# Altium schematic sheet Schematic_Orbita_Motor_Control.SchDoc
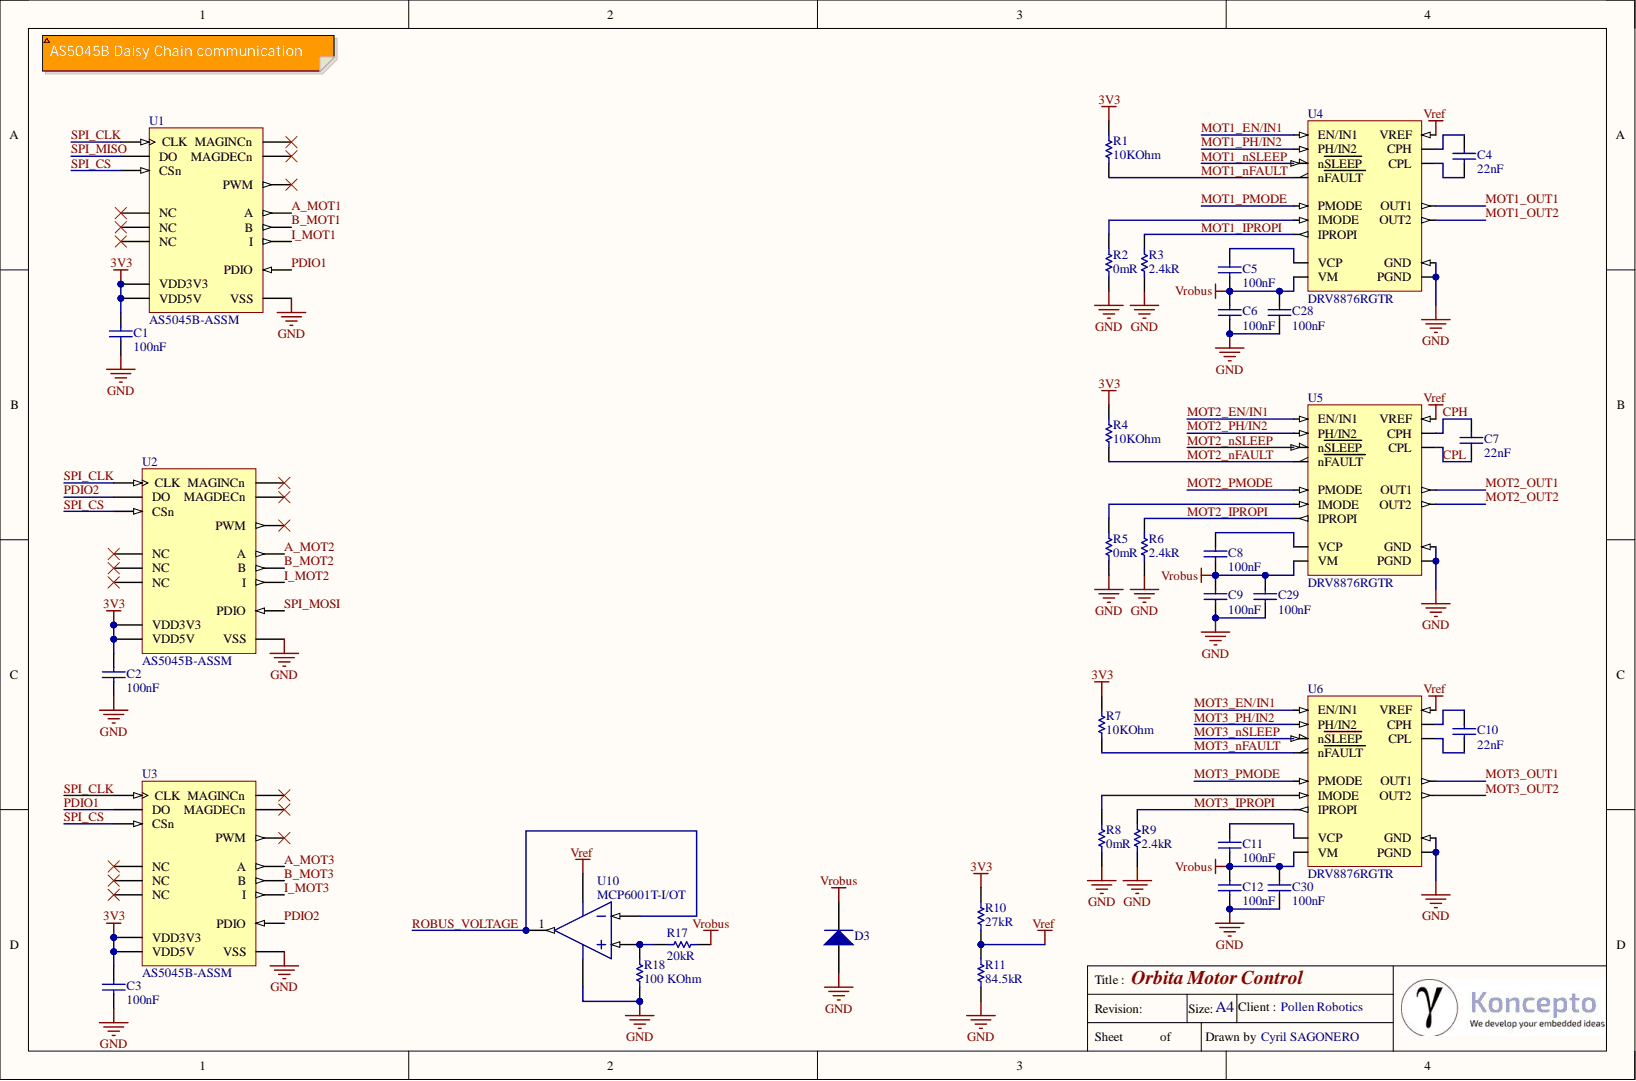
[diagram p0: Schematic_Orbita_Motor_Control.SchDoc]

## Parts
C1  Capacitance: 100nF  [CAPC1005X60X30ML05T10]  (hidden comment: C0402C104K8PACTU)
C2  Capacitance: 100nF  [CAPC1005X60X30ML05T10]  (hidden comment: C0402C104K8PACTU)
C3  Capacitance: 100nF  [CAPC1005X60X30ML05T10]  (hidden comment: C0402C104K8PACTU)
C4  Capacitance: 22nF  [CAPC1005X55X30ML05T10]  (hidden comment: C0402C223K5RACTU)
C5  Capacitance: 100nF  [CAPC1005X55X25ML05T10]  (hidden comment: GRM155R71C104KA88D)
C6  Capacitance: 100nF  [CAPC1005X55X25ML05T10]  (hidden comment: GRM155R71C104KA88D)
C7  Capacitance: 22nF  [CAPC1005X55X30ML05T10]  (hidden comment: C0402C223K5RACTU)
C8  Capacitance: 100nF  [CAPC1005X55X25ML05T10]  (hidden comment: GRM155R71C104KA88D)
C9  Capacitance: 100nF  [CAPC1005X55X25ML05T10]  (hidden comment: GRM155R71C104KA88D)
C10  Capacitance: 22nF  [CAPC1005X55X30ML05T10]  (hidden comment: C0402C223K5RACTU)
C11  Capacitance: 100nF  [CAPC1005X55X25ML05T10]  (hidden comment: GRM155R71C104KA88D)
C12  Capacitance: 100nF  [CAPC1005X55X25ML05T10]  (hidden comment: GRM155R71C104KA88D)
C28  Capacitance: 100nF  [CAPC1005X55X25ML05T10]  (hidden comment: GRM155R71C104KA88D)
C29  Capacitance: 100nF  [CAPC1005X55X25ML05T10]  (hidden comment: GRM155R71C104KA88D)
C30  Capacitance: 100nF  [CAPC1005X55X25ML05T10]  (hidden comment: GRM155R71C104KA88D)
D3  SMAJ13A-E3/61  [VISH-DO-214AC-2_L]  (value not drawn)
R1  Resistance: 10KOhm  [RESC1005X40X25LL05T05]  (hidden comment: ERJ2RKF1002X)
R2  Resistance: 0mR  [RESC1005X40X25NL05T10]  (hidden comment: RC0402JR-070RL)
R3  Resistance: 2.4kR  [RESC2013X60X40LL20T25]  (hidden comment: ERA-6AEB242V)
R4  Resistance: 10KOhm  [RESC1005X40X25LL05T05]  (hidden comment: ERJ2RKF1002X)
R5  Resistance: 0mR  [RESC1005X40X25NL05T10]  (hidden comment: RC0402JR-070RL)
R6  Resistance: 2.4kR  [RESC2013X60X40LL20T25]  (hidden comment: ERA-6AEB242V)
R7  Resistance: 10KOhm  [RESC1005X40X25LL05T05]  (hidden comment: ERJ2RKF1002X)
R8  Resistance: 0mR  [RESC1005X40X25NL05T10]  (hidden comment: RC0402JR-070RL)
R9  Resistance: 2.4kR  [RESC2013X60X40LL20T25]  (hidden comment: ERA-6AEB242V)
R10  Resistance: 27kR  [RESC1005X40X25ML05T05]  (hidden comment: CRCW040227K0FKED)
R11  Resistance: 84.5kR  [RESC1005X40X25ML05T05]  (hidden comment: CRCW040284K5FKED)
R17  Resistance: 20kR  [RESC1005X40X25NL05T05]  (hidden comment: CRCW040220K0FKED)
R18  Resistance: 100 KOhm  [RESC1005X40X25LL05T05]  (hidden comment: ERJ-2RKF1003X)
U1  AS5045B-ASSM  Output Type: PWM  [AMS-SSOP-16-6200X5300DE_L]
U2  AS5045B-ASSM  Output Type: PWM  [AMS-SSOP-16-6200X5300DE_L]
U3  AS5045B-ASSM  Output Type: PWM  [AMS-SSOP-16-6200X5300DE_L]
U4  DRV8876RGTR  [VQFN-16]
U5  DRV8876RGTR  [VQFN-16]
U6  DRV8876RGTR  [VQFN-16]
U10  MCP6001T-I/OT  [SOT-23-OT5_L]

## Nets
3V3: C1.2 C2.2 C3.2 R1.2 R4.1 R7.1 R10.1 U1.15(VDD3V3) U1.16(VDD5V) U2.15(VDD3V3) U2.16(VDD5V) U3.15(VDD3V3) U3.16(VDD5V)
GND: C1.1 C2.1 C3.1 C6.2 C9.2 C12.2 C28.2 C29.2 C30.2 D3.2 R2.2 R3.2 R5.2 R6.2 R8.2 R9.2 R11.2 R18.1 U1.7(VSS) U2.7(VSS) U3.7(VSS) U4.7(PGND) U4.13(GND) U5.7(PGND) U5.13(GND) U6.7(PGND) U6.13(GND) U10.2
Vref: R10.2 R11.1 U4.3(VREF) U5.3(VREF) U6.3(VREF) U10.5
Vrobus: C5.2 C6.1 C8.2 C9.1 C11.2 C12.1 C28.1 C29.1 C30.1 D3.1 R17.1 U4.9(VM) U5.9(VM) U6.9(VM)
A_MOT1: U1.3(A)
A_MOT2: U2.3(A)
A_MOT3: U3.3(A)
B_MOT1: U1.4(B)
B_MOT2: U2.4(B)
B_MOT3: U3.4(B)
CPH: C7.1 U5.11(CPH)
CPL: C7.2 U5.12(CPL)
I_MOT1: U1.6(I)
I_MOT2: U2.6(I)
I_MOT3: U3.6(I)
MOT1_EN/IN1: U4.15(EN/IN1)
MOT1_IPROPI: R3.1 U4.4(IPROPI)
MOT1_nFAULT: R1.1 U4.2(nFAULT)
MOT1_nSLEEP: U4.1(nSLEEP)
MOT1_OUT1: U4.6(OUT1)
MOT1_OUT2: U4.8(OUT2)
MOT1_PH/IN2: U4.16(PH/IN2)
MOT1_PMODE: U4.14(PMODE)
MOT2_EN/IN1: U5.15(EN/IN1)
MOT2_IPROPI: R6.1 U5.4(IPROPI)
MOT2_nFAULT: R4.2 U5.2(nFAULT)
MOT2_nSLEEP: U5.1(nSLEEP)
MOT2_OUT1: U5.6(OUT1)
MOT2_OUT2: U5.8(OUT2)
MOT2_PH/IN2: U5.16(PH/IN2)
MOT2_PMODE: U5.14(PMODE)
MOT3_EN/IN1: U6.15(EN/IN1)
MOT3_IPROPI: R9.1 U6.4(IPROPI)
MOT3_nFAULT: R7.2 U6.2(nFAULT)
MOT3_nSLEEP: U6.1(nSLEEP)
MOT3_OUT1: U6.6(OUT1)
MOT3_OUT2: U6.8(OUT2)
MOT3_PH/IN2: U6.16(PH/IN2)
MOT3_PMODE: U6.14(PMODE)
PDIO1: U1.8(PDIO) U3.9(DO)
PDIO2: U2.9(DO) U3.8(PDIO)
ROBUS_VOLTAGE: U10.1 U10.4
SPI_CLK: U1.10(CLK) U2.10(CLK) U3.10(CLK)
SPI_CS: U1.11(CSn) U2.11(CSn) U3.11(CSn)
SPI_MISO: U1.9(DO)
SPI_MOSI: U2.8(PDIO)
NetC4_1: C4.1 U4.11(CPH)
NetC4_2: C4.2 U4.12(CPL)
NetC5_1: C5.1 U4.10(VCP)
NetC8_1: C8.1 U5.10(VCP)
NetC10_1: C10.1 U6.11(CPH)
NetC10_2: C10.2 U6.12(CPL)
NetC11_1: C11.1 U6.10(VCP)
NetR2_1: R2.1 U4.5(IMODE)
NetR5_1: R5.1 U5.5(IMODE)
NetR8_1: R8.1 U6.5(IMODE)
NetR17_2: R17.2 R18.2 U10.3

Not connected: U1 1(MAGINCn) 2(MAGDECn) 5(NC) 12(PWM) 13(NC) 14(NC); U2 1(MAGINCn) 2(MAGDECn) 5(NC) 12(PWM) 13(NC) 14(NC); U3 1(MAGINCn) 2(MAGDECn) 5(NC) 12(PWM) 13(NC) 14(NC)

## Library data of the parts
C1-C3 = CMP-2008-02046-1: Manufacturer: Kemet; Dielectric: X5R; Dielectric Material: Ceramic; Dissipation Factor: 5%; Tolerance: 10%; Voltage: 10V; Case Code (Imperial): 0402; Case Code (Metric): 1005; Case/Package: 0402; Category: Ceramic Capacitors; Component Kind: Standard; ComponentLink1Description: https://datasheet.ciiva.com/7747/1776086-7747440.pdf?src-supplier=Element14; ComponentLink1URL: https://datasheet.ciiva.com/7747/1776086-7747440.pdf?src-supplier=Element14; ComponentLink2Description: https://datasheet.ciiva.com/144/docfinderpartnumber-c0402c104k8pactu-144097.pdf?src-supplier=Digi-Key; ComponentLink2URL: https://datasheet.ciiva.com/144/docfinderpartnumber-c0402c104k8pactu-144097.pdf?src-supplier=Digi-Key; ComponentLink3Description: https://datasheet.ciiva.com/14595/70095383-14595064.pdf?src-supplier=Allied+Electronics; ComponentLink3URL: https://datasheet.ciiva.com/14595/70095383-14595064.pdf?src-supplier=Allied+Electronics; ComponentLink4Description: https://datasheet.ciiva.com/14588/70095383-14588187.pdf?src-supplier=Allied+Electronics; ComponentLink4URL: https://datasheet.ciiva.com/14588/70095383-14588187.pdf?src-supplier=Allied+Electronics; ComponentLink5Description: https://datasheet.ciiva.com/28645/1789732-28645387.pdf?src-supplier=Element14; ComponentLink5URL: https://datasheet.ciiva.com/28645/1789732-28645387.pdf?src-supplier=Element14; Contact Plating: Tin,Matte; Depth: 0.5mm; Height: 0.5mm; Lead Free: Lead Free; Lead Pitch: 0.3mm; Length: 1mm; Library Name: Altium Content Vault; Manufacturer Part Number: C0402C104K8PACTU; Max Operating Temperature: 85°C; Min Operating Temperature: -55°C; Mount: Surface Mount,PCB; Number of Pins: 2; Package Quantity: 1; Packaging: Tape and Reel; Part Number: C0402C104K8PACTU; Pricing 1: 1=0,25000, 10=0,05500, 100=0,03300, 500=0,02800, 1000=0,02200, 2000=0,01800 (USD); Radiation Hardening: No; REACH SVHC: No SVHC; RoHS: Yes; Stock 1: 986290; Supplier 1: Mouser; Supplier Part Number 1: 80-C0402C104K8P; Termination: SMD/SMT; Thickness: 0.022inch; Voltage Rating: 10V; Voltage Rating (DC): 10V; Width: 0.02inch
C4 C7 C10 = CMP-2008-03151-1: Manufacturer: Kemet; Dielectric: X7R; Dielectric Material: Ceramic; Dissipation Factor: 2.5%; Tolerance: 10%; Voltage: 50V; Case Code (Imperial): 0402; Case Code (Metric): 1005; Case/Package: 0402; Category: Ceramic Capacitors; Component Kind: Standard; ComponentLink1Description: https://datasheet.ciiva.com/14598/70095383-14598149.pdf?src-supplier=Allied+Electronics; ComponentLink1URL: https://datasheet.ciiva.com/14598/70095383-14598149.pdf?src-supplier=Allied+Electronics; ComponentLink2Description: https://datasheet.ciiva.com/7747/40889-7747499.pdf?src-supplier=Element14; ComponentLink2URL: https://datasheet.ciiva.com/7747/40889-7747499.pdf?src-supplier=Element14; ComponentLink3Description: https://datasheet.ciiva.com/7747/40889-7747500.pdf?src-supplier=Element14; ComponentLink3URL: https://datasheet.ciiva.com/7747/40889-7747500.pdf?src-supplier=Element14; ComponentLink4Description: https://datasheet.ciiva.com/14609/70095383-14609037.pdf?src-supplier=Allied+Electronics; ComponentLink4URL: https://datasheet.ciiva.com/14609/70095383-14609037.pdf?src-supplier=Allied+Electronics; Contact Plating: Tin,Matte; Depth: 0.5mm; Height: 0.5mm; Length: 1mm; Library Name: Altium Content Vault; Manufacturer Part Number: C0402C223K5RACTU; Max Operating Temperature: 125°C; Min Operating Temperature: -55°C; Mount: Surface Mount,PCB; Number of Pins: 2; Package Quantity: 1; Packaging: Tape and Reel; Part Number: C0402C223K5RACTU; Pricing 1: 1=0,11000, 10=0,08000, 100=0,03500, 500=0,02500, 1000=0,01900, 2000=0,01600 (USD); Radiation Hardening: No; REACH SVHC: No SVHC; RoHS: Yes; Stock 1: 119647; Supplier 1: Mouser; Supplier Part Number 1: 80-C0402C223K5R; Termination: SMD/SMT; Thickness: 0.022inch; Voltage Rating: 50V; Voltage Rating (DC): 50V; Width: 0.5mm
C5 C6 C8 C9 C11 C12 C28-C30 = CMP-2100-03641-1: Chip Capacitor, 0.1 uF, +/- 10%, 16 V, -55 to 125 degC, 0402 (1005 Metric), RoHS, Tape and Reel; Manufacturer: Murata; Dielectric: X7R; Dielectric Material: Ceramic; Insulation Resistance: 10GR; Voltage: 16V; Case Code (Imperial): 0402; Case Code (Metric): 1005; Case/Package: 0402; Category: Ceramic Capacitors; Component Kind: Standard; ComponentLink1Description: https://datasheet.ciiva.com/129/grm155r71c104ka88-01-129739.pdf?src-supplier=Digi-Key; ComponentLink1URL: https://datasheet.ciiva.com/129/grm155r71c104ka88-01-129739.pdf?src-supplier=Digi-Key; ComponentLink2Description: https://datasheet.ciiva.com/129/grm155r71c104ka88-01-129740.pdf?src-supplier=Digi-Key; ComponentLink2URL: https://datasheet.ciiva.com/129/grm155r71c104ka88-01-129740.pdf?src-supplier=Digi-Key; ComponentLink3Description: https://datasheet.ciiva.com/18102/getdatasheetpartid-85307-18102905.pdf?src-supplier=Verical; ComponentLink3URL: https://datasheet.ciiva.com/18102/getdatasheetpartid-85307-18102905.pdf?src-supplier=Verical; ComponentLink4Description: https://datasheet.ciiva.com/29199/454098-da-01-en-vs-kondensator-0402-29199799.pdf?src-supplier=Conrad; ComponentLink4URL: https://datasheet.ciiva.com/29199/454098-da-01-en-vs-kondensator-0402-29199799.pdf?src-supplier=Conrad; ComponentLink5Description: https://datasheet.ciiva.com/16199/product-16199000.pdf?src-supplier=Future+Electronics; ComponentLink5URL: https://datasheet.ciiva.com/16199/product-16199000.pdf?src-supplier=Future+Electronics; ComponentLink6Description: https://datasheet.ciiva.com/14116/grmseries-highdielectrictype-eng-14116878.pdf?src-supplier=Distrelec; ComponentLink6URL: https://datasheet.ciiva.com/14116/grmseries-highdielectrictype-eng-14116878.pdf?src-supplier=Distrelec; ComponentLink7Description: https://datasheet.ciiva.com/7937/4360-7937615.pdf?src-supplier=Element14; ComponentLink7URL: https://datasheet.ciiva.com/7937/4360-7937615.pdf?src-supplier=Element14; ComponentLink8Description: https://datasheet.ciiva.com/28534/4360-28534133.pdf?src-supplier=Element14; ComponentLink8URL: https://datasheet.ciiva.com/28534/4360-28534133.pdf?src-supplier=Element14; ComponentLink9Description: https://datasheet.ciiva.com/14103/grmseries-highdielectrictype-eng-14103750.pdf?src-supplier=Distrelec; ComponentLink9URL: https://datasheet.ciiva.com/14103/grmseries-highdielectrictype-eng-14103750.pdf?src-supplier=Distrelec; ComponentLink10Description: https://datasheet.ciiva.com/28534/4360-28534127.pdf?src-supplier=Element14; ComponentLink10URL: https://datasheet.ciiva.com/28534/4360-28534127.pdf?src-supplier=Element14; Datasheet URL: http://psearch.en.murata.com/capacitor/product/GRM155R71C104KA88%23.pdf; Datasheet Version: 42675.0; Depth: 0.5mm; Height: 0.5mm; Lead Free: LeadFree; Length: 1mm; Library Name: Altium Content Vault; Manufacturer Part Number: GRM155R71C104KA88D; Manufacturer URL: http://www.murata.com/; Material: Ceramic; Max Operating Temperature: 125degC; Min Operating Temperature: -55degC; Mount: SurfaceMount; Number of Pins: 2; Package Description: 2-Pin Surface Mount Device, Body 1 x 0.5 mm; Package Quantity: 1; Package Reference: 0402; Packaging: TapeandReel; Pricing 1: 1=0,10000, 10=0,02400, 100=0,01000, 500=0,00700, 1000=0,00500, 2500=0,00500 (USD); Radiation Hardening: No; REACH SVHC: NoSVHC; RoHS: Yes; RoHSCompliant: true; Stock 1: 3630519; Supplier 1: Mouser; Supplier Part Number 1: 81-GRM155R71C104KA88; Termination: SMD/SMT; Thickness: 0.022inch; Tolerance: 10%; Voltage Rating: 16V; Voltage Rating (DC): 16V; Width: 0.5mm
D3 = CMP-0548-00232-2: Transient Voltage Suppressor, 6.40 to 231 V, 13 V Stand-off Voltage, Uni-Directional, -55 to 155 degC, 2-pin DO-214AC, Pb-Free, Tape and Reel; Manufacturer: Vishay Semiconductor; Breakdown Voltage: 14.4V; Clamping Voltage: 21.5V; Direction: Unidirectional; Element Configuration: Single; ID (uA): 1; IFSM: 40 A; Leakage Current: 1uA; Max Breakdown Voltage: 15.9V; Max Forward Surge Current (Ifsm): 40A; Max Power Dissipation: 400W; Max Surge Current: 18.6A; Operating Supply Voltage: 13V; PPPM (W): 400, 300; Test Current: 1mA; VBR Uni-Directional (V): 6.4 to 231; VWM (V): 13; Case/Package: SMA; Category: TVS Diodes; Component Kind: Standard; ComponentLink1Description: Manufacturer URL; ComponentLink1URL: http://www.vishay.com/; ComponentLink2Description: Datasheet; ComponentLink2URL: http://www.vishay.com/docs/88390/smaj50a.pdf; ComponentLink3Description: Package Specification; ComponentLink3URL: http://www.vishay.com/docs/88390/smaj50a.pdf; ComponentLink4Description: https://datasheet.ciiva.com/4247/2smaj50a-4247590.pdf?src-supplier=Arrow; ComponentLink4URL: https://datasheet.ciiva.com/4247/2smaj50a-4247590.pdf?src-supplier=Arrow; ComponentLink5Description: https://datasheet.ciiva.com/26912/getdatasheetpartid-161855-26912429.pdf?src-supplier=Verical; ComponentLink5URL: https://datasheet.ciiva.com/26912/getdatasheetpartid-161855-26912429.pdf?src-supplier=Verical; ComponentLink6Description: https://datasheet.ciiva.com/16295/smaj50a-16295263.pdf?src-supplier=Future+Electronics; ComponentLink6URL: https://datasheet.ciiva.com/16295/smaj50a-16295263.pdf?src-supplier=Future+Electronics; ComponentLink7Description: https://datasheet.ciiva.com/330/88390-330645.pdf?src-supplier=Digi-Key; ComponentLink7URL: https://datasheet.ciiva.com/330/88390-330645.pdf?src-supplier=Digi-Key; ComponentLink8Description: https://datasheet.ciiva.com/26322/548547-26322539.pdf?src-supplier=Element14; ComponentLink8URL: https://datasheet.ciiva.com/26322/548547-26322539.pdf?src-supplier=Element14; ComponentLink9Description: https://datasheet.ciiva.com/4510/smaj50a-241759-4510631.pdf?src-supplier=Mouser; ComponentLink9URL: https://datasheet.ciiva.com/4510/smaj50a-241759-4510631.pdf?src-supplier=Mouser; Contact Plating: Tin,Matte; DatasheetVersion: 12/8/2013; Lead Free: Lead Free; Library Name: Altium Content Vault; Manufacturer Part Number: SMAJ13A-E3/61; Max Operating Temperature: 150°C; Max Reverse Leakage Current: 1uA; Min Breakdown Voltage: 14.4V; Min Operating Temperature: -55°C; Mounting Technology: Surface Mount; Number of Channels: 1; Number of Elements: 1; Number of Pins: 2; Operating Junction and Storage Temperature Range: -55 to 150 degC; Package Quantity: 1800; PackageDescription: 2-Pin DO-214AC (SMA), Body 4.245 x 2.665 mm; PackageReference: DO-214AC-2; Packaging: Tape and Reel; Packing: Tape and Reel; PartNumber: SMAJ13A-E3/61; Peak Pulse Current: 18.6A; Peak Pulse Power: 400W; Polarity: Unidirectional; Power Dissipation: 400W; Pricing 1: 1=0,37000, 10=0,27100, 100=0,16600, 500=0,13500, 1000=0,11800, 1800=0,09500 (USD); Radiation Hardening: No; REACH SVHC: Unknown; Reverse Breakdown Voltage: 14.4V; Reverse Standoff Voltage: 13V; RoHS: TRUE; Stock 1: 2691; Supplier 1: Mouser; Supplier Part Number 1: 625-SMAJ13A-E3; Termination: SMD/SMT
R1 R4 R7 = CMP-2000-07588-1: Chip Resistor, 10 KOhm, +/- 1%, 0.1 W, -55 to 155 degC, 0402 (1005 Metric), RoHS, Tape and Reel; Manufacturer: Panasonic; Manufacturer Part Number: ERJ-2RKF1002X; Case\/Package: 0402; Max Power Dissipation: 100mW; Temperature Coefficient: 100ppm\/degreesC; Voltage Rating: 50V; Case Code (Imperial): 0402; Case Code (Metric): 1005; Case/Package: 0402; Category: Chip SMD Resistors; Component Kind: Standard; ComponentLink1Description: https://datasheet.ciiva.com/11821/0900766b80f80784-11821171.pdf?src-supplier=RSComponents; ComponentLink1URL: https://datasheet.ciiva.com/11821/0900766b80f80784-11821171.pdf?src-supplier=RSComponents; ComponentLink2Description: https://datasheet.ciiva.com/16199/aoa0000ce2-16199478.pdf?src-supplier=Future+Electronics; ComponentLink2URL: https://datasheet.ciiva.com/16199/aoa0000ce2-16199478.pdf?src-supplier=Future+Electronics; ComponentLink3Description: https://datasheet.ciiva.com/17212/aoa0000ce2-17212102.pdf?src-supplier=Avnet+Europe; ComponentLink3URL: https://datasheet.ciiva.com/17212/aoa0000ce2-17212102.pdf?src-supplier=Avnet+Europe; ComponentLink4Description: https://datasheet.ciiva.com/24023/aoa0000ce2-24023017.pdf?src-supplier=Mouser; ComponentLink4URL: https://datasheet.ciiva.com/24023/aoa0000ce2-24023017.pdf?src-supplier=Mouser; ComponentLink5Description: https://datasheet.ciiva.com/28514/1678782-28514185.pdf?src-supplier=Element14; ComponentLink5URL: https://datasheet.ciiva.com/28514/1678782-28514185.pdf?src-supplier=Element14; ComponentLink6Description: https://datasheet.ciiva.com/43467/1678782-43467402.pdf?src-supplier=Farnell; ComponentLink6URL: https://datasheet.ciiva.com/43467/1678782-43467402.pdf?src-supplier=Farnell; ComponentLink7Description: https://datasheet.ciiva.com/27055/1987020-27055643.pdf?src-supplier=Jameco; ComponentLink7URL: https://datasheet.ciiva.com/27055/1987020-27055643.pdf?src-supplier=Jameco; ComponentLink8Description: https://datasheet.ciiva.com/48531/aoa0000ce2-48531069.pdf?src-supplier=Avnet; ComponentLink8URL: https://datasheet.ciiva.com/48531/aoa0000ce2-48531069.pdf?src-supplier=Avnet; ComponentLink9Description: https://datasheet.ciiva.com/9194/aoa0000ce2-9194142.pdf?src-supplier=Avnet; ComponentLink9URL: https://datasheet.ciiva.com/9194/aoa0000ce2-9194142.pdf?src-supplier=Avnet; ComponentLink10Description: https://datasheet.ciiva.com/16782/70260748-16782590.pdf?src-supplier=Allied+Electronics; ComponentLink10URL: https://datasheet.ciiva.com/16782/70260748-16782590.pdf?src-supplier=Allied+Electronics; ComponentLink11Description: https://datasheet.ciiva.com/16786/70260748-16786734.pdf?src-supplier=Allied+Electronics; ComponentLink11URL: https://datasheet.ciiva.com/16786/70260748-16786734.pdf?src-supplier=Allied+Electronics; ComponentLink12Description: https://datasheet.ciiva.com/30201/aoa0000ce2-30201022.pdf?src-supplier=Onlinecomponents.com; ComponentLink12URL: https://datasheet.ciiva.com/30201/aoa0000ce2-30201022.pdf?src-supplier=Onlinecomponents.com; Composition: ThickFilm; Contact Plating: Tin; Datasheet URL: https://industrial.panasonic.com/cdbs/www-data/pdf/RDA0000/AOA0000C304.pdf; Datasheet Version: 02/2017; Depth: 0.5mm; Features: Automotive AEC-Q200; Height: 0.35mm; Lead Free: LeadFree; Length: 1mm; Library Name: Altium Content Vault; Manufacturer URL: http://www.panasonic.com/; Max Operating Temperature: 155degC; Military Standard: Not; Min Operating Temperature: -55degC; Mount: SurfaceMount; Mounting Technology: SurfaceMount; Number of Pins: 2; Number of Terminations: 2; Package Description: 2-Pin Surface Mount Device, Body 1 x 0.5 mm; Package Quantity: 1; Package Reference: 402; Packaging: TapeandReel; Power Rating: 100mW; Pricing 1: 1=0,10000, 10=0,04500, 100=0,01800, 1000=0,00800, 2500=0,00500, 10000=0,00400 (USD); Radiation Hardening: No; REACH SVHC: NoSVHC; RoHS: Yes; RoHSCompliant: True; Stock 1: 3764496; Supplier 1: Mouser; Supplier Part Number 1: 667-ERJ-2RKF1002X; Termination: SMD/SMT; Tolerance: 1%; Voltage Rating (DC): 50V; Width: 0.02inch
R2 R5 R8 = CMP-2002-07912-1: Manufacturer: Yageo / Phycomp; Max Power Dissipation: 63mW; Power Rating: 62.5mW; Temperature Coefficient: 200ppm/°C; Tolerance: 5%; Voltage Rating: 50V; Case Code (Imperial): 0402; Case Code (Metric): 1005; Case/Package: 0402; Category: Chip SMD Resistors; Component Kind: Standard; ComponentLink1Description: https://datasheet.ciiva.com/2452/pyu-rc0402-51-rohs-l-6-2452608.pdf?src-supplier=Digi-Key; ComponentLink1URL: https://datasheet.ciiva.com/2452/pyu-rc0402-51-rohs-l-6-2452608.pdf?src-supplier=Digi-Key; ComponentLink2Description: https://datasheet.ciiva.com/11808/0900766b80c66297-11808195.pdf?src-supplier=RSComponents; ComponentLink2URL: https://datasheet.ciiva.com/11808/0900766b80c66297-11808195.pdf?src-supplier=RSComponents; ComponentLink3Description: https://datasheet.ciiva.com/2452/pyu-r-int-thick-7-2452609.pdf?src-supplier=Digi-Key; ComponentLink3URL: https://datasheet.ciiva.com/2452/pyu-r-int-thick-7-2452609.pdf?src-supplier=Digi-Key; ComponentLink4Description: https://datasheet.ciiva.com/2452/pyu-r-int-thick-7-2452610.pdf?src-supplier=Digi-Key; ComponentLink4URL: https://datasheet.ciiva.com/2452/pyu-r-int-thick-7-2452610.pdf?src-supplier=Digi-Key; ComponentLink5Description: https://datasheet.ciiva.com/8896/pyu-rc0402-51-rohs-l-6-8896201.pdf?src-supplier=Avnet; ComponentLink5URL: https://datasheet.ciiva.com/8896/pyu-rc0402-51-rohs-l-6-8896201.pdf?src-supplier=Avnet; ComponentLink6Description: https://datasheet.ciiva.com/28386/pyu-rc0402-51-rohs-l-6-28386633.pdf?src-supplier=Avnet+Europe; ComponentLink6URL: https://datasheet.ciiva.com/28386/pyu-rc0402-51-rohs-l-6-28386633.pdf?src-supplier=Avnet+Europe; ComponentLink7Description: https://datasheet.ciiva.com/22747/1018594-22747515.pdf?src-supplier=Element14; ComponentLink7URL: https://datasheet.ciiva.com/22747/1018594-22747515.pdf?src-supplier=Element14; ComponentLink8Description: https://datasheet.ciiva.com/15189/getdatasheetpartid-326379-15189533.pdf?src-supplier=Verical; ComponentLink8URL: https://datasheet.ciiva.com/15189/getdatasheetpartid-326379-15189533.pdf?src-supplier=Verical; ComponentLink9Description: https://datasheet.ciiva.com/7983/1018594-7983535.pdf?src-supplier=Element14; ComponentLink9URL: https://datasheet.ciiva.com/7983/1018594-7983535.pdf?src-supplier=Element14; Composition: Thick Film; Contact Plating: Tin,Matte; Depth: 0.5mm; Features: Moisture Resistant; Height: 0.016inch; Lead Free: Lead Free; Length: 1mm; Library Name: Altium Content Vault; Manufacturer Part Number: RC0402JR-070RL; Max Operating Temperature: 155°C; Military Standard: Not; Min Operating Temperature: -55°C; Number of Terminations: 2; Package Quantity: 1; Packaging: Tape and Reel; Part Number: RC0402JR-070RL; Pricing 1: 1=0,10000, 10=0,01000, 100=0,00400, 1000=0,00300, 2500=0,00200, 10000=0,00200 (USD); Radiation Hardening: No; REACH SVHC: Unknown; RoHS: Yes; Stock 1: 753027; Supplier 1: Mouser; Supplier Part Number 1: 603-RC0402JR-070RL; Termination: SMD/SMT; Width: 0.02inch
R3 R6 R9 = CMP-2001-00617-1: Manufacturer: Panasonic; Max Power Dissipation: 125mW; Temperature Coefficient: 25ppm/°C; Tolerance: 0.1%; Voltage Rating: 100V; Case Code (Imperial): 0805; Case Code (Metric): 2012; Case/Package: 0805; Category: Chip SMD Resistors; Component Kind: Standard; ComponentLink1Description: https://datasheet.ciiva.com/29184/453148-da-01-en-widerstand-0805-era-29184129.pdf?src-supplier=Conrad; ComponentLink1URL: https://datasheet.ciiva.com/29184/453148-da-01-en-widerstand-0805-era-29184129.pdf?src-supplier=Conrad; ComponentLink2Description: https://datasheet.ciiva.com/22737/1776116-22737989.pdf?src-supplier=Element14; ComponentLink2URL: https://datasheet.ciiva.com/22737/1776116-22737989.pdf?src-supplier=Element14; ComponentLink3Description: https://datasheet.ciiva.com/48536/era-eng-tds-48536694.pdf?src-supplier=Distrelec; ComponentLink3URL: https://datasheet.ciiva.com/48536/era-eng-tds-48536694.pdf?src-supplier=Distrelec; ComponentLink4Description: https://datasheet.ciiva.com/48425/era-eng-tds-48425783.pdf?src-supplier=Distrelec; ComponentLink4URL: https://datasheet.ciiva.com/48425/era-eng-tds-48425783.pdf?src-supplier=Distrelec; ComponentLink5Description: https://datasheet.ciiva.com/7891/485442-7891209.pdf?src-supplier=Element14; ComponentLink5URL: https://datasheet.ciiva.com/7891/485442-7891209.pdf?src-supplier=Element14; ComponentLink6Description: https://datasheet.ciiva.com/17214/aoa0000ce26-17214092.pdf?src-supplier=Avnet+Europe; ComponentLink6URL: https://datasheet.ciiva.com/17214/aoa0000ce26-17214092.pdf?src-supplier=Avnet+Europe; ComponentLink7Description: https://datasheet.ciiva.com/9197/aoa0000ce26-9197183.pdf?src-supplier=Avnet; ComponentLink7URL: https://datasheet.ciiva.com/9197/aoa0000ce26-9197183.pdf?src-supplier=Avnet; ComponentLink8Description: https://datasheet.ciiva.com/5875/485442-5875519.pdf?src-supplier=Farnell; ComponentLink8URL: https://datasheet.ciiva.com/5875/485442-5875519.pdf?src-supplier=Farnell; Composition: Thin Film,Metal Film; Contact Plating: Tin; Depth: 1.25mm; Features: Automotive AEC-Q200; Height: 0.5mm; Lead Free: Lead Free; Length: 2mm; Library Name: Altium Content Vault; Manufacturer Part Number: ERA-6AEB242V; Max Operating Temperature: 155°C; Military Standard: Not; Min Operating Temperature: -55°C; Mount: Surface Mount; Number of Pins: 2; Number of Terminations: 2; Package Quantity: 1; Packaging: Tape and Reel; Part Number: ERA-6AEB242V; Power Rating: 125mW; Pricing 1: 1=0,36000, 10=0,30200, 100=0,11800, 1000=0,04500, 5000=0,03700, 10000=0,03400 (USD); Radiation Hardening: No; REACH SVHC: No SVHC; RoHS: Yes; Stock 1: 8004; Supplier 1: Mouser; Supplier Part Number 1: 667-ERA-6AEB242V; Termination: SMD/SMT; Voltage Rating (DC): 100V; Weight: 0.000194oz; Width: 1.25mm
R10 = CMP-2002-08431-1: Manufacturer: Vishay Dale; Max Power Dissipation: 63mW; Temperature Coefficient: 100ppm/°C; Tolerance: 1%; Voltage Rating: 50V; Case Code (Imperial): 0402; Case Code (Metric): 1005; Case/Package: 0402; Category: Chip SMD Resistors; Component Kind: Standard; ComponentLink1Description: https://datasheet.ciiva.com/11811/0900766b80d98824-11811417.pdf?src-supplier=RSComponents; ComponentLink1URL: https://datasheet.ciiva.com/11811/0900766b80d98824-11811417.pdf?src-supplier=RSComponents; ComponentLink2Description: https://datasheet.ciiva.com/2463/dcrcwe3-2463552.pdf?src-supplier=Digi-Key; ComponentLink2URL: https://datasheet.ciiva.com/2463/dcrcwe3-2463552.pdf?src-supplier=Digi-Key; ComponentLink3Description: https://datasheet.ciiva.com/5545/dcrcwe3-109170-5545461.pdf?src-supplier=Mouser; ComponentLink3URL: https://datasheet.ciiva.com/5545/dcrcwe3-109170-5545461.pdf?src-supplier=Mouser; ComponentLink4Description: https://datasheet.ciiva.com/22870/70201119-22870583.pdf?src-supplier=Allied+Electronics; ComponentLink4URL: https://datasheet.ciiva.com/22870/70201119-22870583.pdf?src-supplier=Allied+Electronics; ComponentLink5Description: https://datasheet.ciiva.com/16886/dcrcwe3-16886843.pdf?src-supplier=Avnet+Europe; ComponentLink5URL: https://datasheet.ciiva.com/16886/dcrcwe3-16886843.pdf?src-supplier=Avnet+Europe; ComponentLink6Description: https://datasheet.ciiva.com/22708/1697337-22708412.pdf?src-supplier=Element14; ComponentLink6URL: https://datasheet.ciiva.com/22708/1697337-22708412.pdf?src-supplier=Element14; ComponentLink7Description: https://datasheet.ciiva.com/2463/dcrcwe3-2463550.pdf?src-supplier=Digi-Key; ComponentLink7URL: https://datasheet.ciiva.com/2463/dcrcwe3-2463550.pdf?src-supplier=Digi-Key; ComponentLink8Description: https://datasheet.ciiva.com/16210/dcrcwe3-16210014.pdf?src-supplier=Future+Electronics; ComponentLink8URL: https://datasheet.ciiva.com/16210/dcrcwe3-16210014.pdf?src-supplier=Future+Electronics; ComponentLink9Description: https://datasheet.ciiva.com/2463/dcrcwe3-2463551.pdf?src-supplier=Digi-Key; ComponentLink9URL: https://datasheet.ciiva.com/2463/dcrcwe3-2463551.pdf?src-supplier=Digi-Key; ComponentLink10Description: https://datasheet.ciiva.com/16777/70201119-16777471.pdf?src-supplier=Allied+Electronics; ComponentLink10URL: https://datasheet.ciiva.com/16777/70201119-16777471.pdf?src-supplier=Allied+Electronics; ComponentLink11Description: https://datasheet.ciiva.com/26316/fld-crcw-e3-data-en-26316623.pdf?src-supplier=Distrelec; ComponentLink11URL: https://datasheet.ciiva.com/26316/fld-crcw-e3-data-en-26316623.pdf?src-supplier=Distrelec; Composition: Thick Film; Contact Plating: Tin; Depth: 0.5mm; Features: Automotive AEC-Q200; Height: 0.35mm; Lead Free: Lead Free; Length: 1mm; Library Name: Altium Content Vault; Manufacturer Part Number: CRCW040227K0FKED; Max Operating Temperature: 155°C; Military Standard: Not; Min Operating Temperature: -55°C; Mount: Surface Mount; Number of Pins: 2; Number of Terminations: 2; Package Quantity: 10; Packaging: Tape and Reel; Part Number: CRCW040227K0FKED; Power Rating: 63mW; Pricing 1: 1=0,10000, 10=0,03900, 100=0,02700, 1000=0,01500, 10000=0,01000, 30000=0,00600 (USD); Radiation Hardening: No; REACH SVHC: Unknown; RoHS: Yes; Stock 1: 26797; Supplier 1: Mouser; Supplier Part Number 1: 71-CRCW040227K0FKED; Termination: SMD/SMT; Voltage Rating (DC): 50V; Weight: 2.3E-05oz; Width: 0.02inch
R11 = CMP-2002-00243-1: Manufacturer: Vishay Dale; Max Power Dissipation: 63mW; Temperature Coefficient: 100ppm/°C; Tolerance: 1%; Voltage Rating: 50V; Case Code (Imperial): 0402; Case Code (Metric): 1005; Case/Package: 0402; Category: Chip SMD Resistors; Component Kind: Standard; ComponentLink1Description: https://datasheet.ciiva.com/7816/1697337-7816022.pdf?src-supplier=Element14; ComponentLink1URL: https://datasheet.ciiva.com/7816/1697337-7816022.pdf?src-supplier=Element14; ComponentLink2Description: https://datasheet.ciiva.com/22869/70201119-22869855.pdf?src-supplier=Allied+Electronics; ComponentLink2URL: https://datasheet.ciiva.com/22869/70201119-22869855.pdf?src-supplier=Allied+Electronics; ComponentLink3Description: https://datasheet.ciiva.com/3256/dcrcwe3-3256853.pdf?src-supplier=Avnet; ComponentLink3URL: https://datasheet.ciiva.com/3256/dcrcwe3-3256853.pdf?src-supplier=Avnet; ComponentLink4Description: https://datasheet.ciiva.com/7816/1697337-7816021.pdf?src-supplier=Element14; ComponentLink4URL: https://datasheet.ciiva.com/7816/1697337-7816021.pdf?src-supplier=Element14; ComponentLink5Description: https://datasheet.ciiva.com/5552/dcrcwe3-109170-5552008.pdf?src-supplier=Mouser; ComponentLink5URL: https://datasheet.ciiva.com/5552/dcrcwe3-109170-5552008.pdf?src-supplier=Mouser; ComponentLink6Description: https://datasheet.ciiva.com/22708/1697337-22708056.pdf?src-supplier=Element14; ComponentLink6URL: https://datasheet.ciiva.com/22708/1697337-22708056.pdf?src-supplier=Element14; ComponentLink7Description: https://datasheet.ciiva.com/16770/70201119-16770090.pdf?src-supplier=Allied+Electronics; ComponentLink7URL: https://datasheet.ciiva.com/16770/70201119-16770090.pdf?src-supplier=Allied+Electronics; ComponentLink8Description: https://datasheet.ciiva.com/2464/dcrcwe3-2464555.pdf?src-supplier=Digi-Key; ComponentLink8URL: https://datasheet.ciiva.com/2464/dcrcwe3-2464555.pdf?src-supplier=Digi-Key; ComponentLink9Description: https://datasheet.ciiva.com/2464/dcrcwe3-2464556.pdf?src-supplier=Digi-Key; ComponentLink9URL: https://datasheet.ciiva.com/2464/dcrcwe3-2464556.pdf?src-supplier=Digi-Key; ComponentLink10Description: https://datasheet.ciiva.com/2464/dcrcwe3-2464557.pdf?src-supplier=Digi-Key; ComponentLink10URL: https://datasheet.ciiva.com/2464/dcrcwe3-2464557.pdf?src-supplier=Digi-Key; Composition: Thick Film; Contact Plating: Tin; Depth: 0.5mm; Features: Automotive AEC-Q200; Height: 0.35mm; Lead Free: Lead Free; Length: 1mm; Library Name: Altium Content Vault; Manufacturer Part Number: CRCW040284K5FKED; Max Operating Temperature: 155°C; Military Standard: Not; Min Operating Temperature: -55°C; Mount: Surface Mount; Number of Pins: 2; Number of Terminations: 2; Package Quantity: 10; Packaging: Tape and Reel; Part Number: CRCW040284K5FKED; Power Rating: 63mW; Pricing 1: 1=0,10000, 10=0,03900, 100=0,01500, 1000=0,01000, 10000=0,00600, 30000=0,00400 (USD); Radiation Hardening: No; REACH SVHC: Unknown; RoHS: Yes; Stock 1: 9984; Supplier 1: Mouser; Supplier Part Number 1: 71-CRCW0402-84.5K-E3; Termination: SMD/SMT; Voltage Rating (DC): 50V; Weight: 2.3E-05oz; Width: 0.5mm
R17 = CMP-2002-08356-1: Manufacturer: Vishay Dale; Max Power Dissipation: 63mW; Temperature Coefficient: 100ppm/°C; Tolerance: 1%; Voltage Rating: 50V; Case Code (Imperial): 0402; Case Code (Metric): 1005; Case/Package: 0402; Category: Chip SMD Resistors; Component Kind: Standard; ComponentLink1Description: https://datasheet.ciiva.com/11810/0900766b80d98824-11810023.pdf?src-supplier=RSComponents; ComponentLink1URL: https://datasheet.ciiva.com/11810/0900766b80d98824-11810023.pdf?src-supplier=RSComponents; ComponentLink2Description: https://datasheet.ciiva.com/2463/dcrcwe3-2463139.pdf?src-supplier=Digi-Key; ComponentLink2URL: https://datasheet.ciiva.com/2463/dcrcwe3-2463139.pdf?src-supplier=Digi-Key; ComponentLink3Description: https://datasheet.ciiva.com/2463/dcrcwe3-2463140.pdf?src-supplier=Digi-Key; ComponentLink3URL: https://datasheet.ciiva.com/2463/dcrcwe3-2463140.pdf?src-supplier=Digi-Key; ComponentLink4Description: https://datasheet.ciiva.com/2463/dcrcwe3-2463141.pdf?src-supplier=Digi-Key; ComponentLink4URL: https://datasheet.ciiva.com/2463/dcrcwe3-2463141.pdf?src-supplier=Digi-Key; ComponentLink5Description: https://datasheet.ciiva.com/3256/dcrcwe3-3256213.pdf?src-supplier=Avnet; ComponentLink5URL: https://datasheet.ciiva.com/3256/dcrcwe3-3256213.pdf?src-supplier=Avnet; ComponentLink6Description: https://datasheet.ciiva.com/16886/dcrcwe3-16886581.pdf?src-supplier=Avnet+Europe; ComponentLink6URL: https://datasheet.ciiva.com/16886/dcrcwe3-16886581.pdf?src-supplier=Avnet+Europe; ComponentLink7Description: https://datasheet.ciiva.com/15159/getdatasheetpartid-93312-15159927.pdf?src-supplier=Verical; ComponentLink7URL: https://datasheet.ciiva.com/15159/getdatasheetpartid-93312-15159927.pdf?src-supplier=Verical; ComponentLink8Description: https://datasheet.ciiva.com/16725/70201119-16725214.pdf?src-supplier=Allied+Electronics; ComponentLink8URL: https://datasheet.ciiva.com/16725/70201119-16725214.pdf?src-supplier=Allied+Electronics; Composition: Thick Film; Contact Plating: Tin; Depth: 0.5mm; Features: Automotive AEC-Q200; Height: 0.35mm; Lead Free: Lead Free; Length: 1mm; Library Name: Altium Content Vault; Manufacturer Part Number: CRCW040220K0FKED; Max Operating Temperature: 155°C; Military Standard: Not; Min Operating Temperature: -55°C; Mount: Surface Mount; Number of Pins: 2; Number of Terminations: 2; Package Quantity: 10; Packaging: Tape and Reel; Part Number: CRCW040220K0FKED; Power Rating: 63mW; Pricing 1: 1=0,10000, 10=0,04200, 100=0,02900, 1000=0,01600, 10000=0,01100, 30000=0,00700 (USD); Radiation Hardening: No; REACH SVHC: Unknown; RoHS: Yes; Stock 1: 78560; Supplier 1: Mouser; Supplier Part Number 1: 71-CRCW0402-20K-E3; Termination: SMD/SMT; Voltage Rating (DC): 50V; Weight: 2.3E-05oz; Width: 0.5mm; Working Voltage: 50V
R18 = CMP-2002-00608-3: Chip Resistor, 100 KOhm, +/- 1%, 100 mW, -55 to 155 degC, 0402 (1005 Metric), RoHS, Tape and Reel; Manufacturer: Basic Passives; Case\/Package: 0402; Max Power Dissipation: 100mW; Power Rating: 100 mW; Temperature Coefficient: 100ppm/°C; Voltage Rating: 50 V; Voltage Rating (DC): 50V; Case Code (Imperial): 0402; Case Code (Metric): 1005; Case/Package: 0402; Category: Chip SMD Resistors; Component Kind: Standard; ComponentLink1Description: Manufacturer URL; ComponentLink1URL: http://www.panasonic.com/; ComponentLink2Description: Datasheet; ComponentLink2URL: http://industrial.panasonic.com/cdbs/www-data/pdf/RDA0000/AOA0000C86.pdf; ComponentLink3Description: https://datasheet.ciiva.com/11821/0900766b80f80784-11821175.pdf?src-supplier=RSComponents; ComponentLink3URL: https://datasheet.ciiva.com/11821/0900766b80f80784-11821175.pdf?src-supplier=RSComponents; ComponentLink4Description: https://datasheet.ciiva.com/34303/1987038-34303550.pdf?src-supplier=Jameco; ComponentLink4URL: https://datasheet.ciiva.com/34303/1987038-34303550.pdf?src-supplier=Jameco; ComponentLink5Description: https://datasheet.ciiva.com/7830/aoa0000ce2-7830725.pdf?src-supplier=Element14; ComponentLink5URL: https://datasheet.ciiva.com/7830/aoa0000ce2-7830725.pdf?src-supplier=Element14; ComponentLink6Description: https://datasheet.ciiva.com/16522/aoa0000ce2-16522647.pdf?src-supplier=Avnet+Asia; ComponentLink6URL: https://datasheet.ciiva.com/16522/aoa0000ce2-16522647.pdf?src-supplier=Avnet+Asia; ComponentLink7Description: https://datasheet.ciiva.com/43467/1678782-43467480.pdf?src-supplier=Farnell; ComponentLink7URL: https://datasheet.ciiva.com/43467/1678782-43467480.pdf?src-supplier=Farnell; ComponentLink8Description: https://datasheet.ciiva.com/16440/aoa0000ce2-16440217.pdf?src-supplier=Future+Electronics; ComponentLink8URL: https://datasheet.ciiva.com/16440/aoa0000ce2-16440217.pdf?src-supplier=Future+Electronics; ComponentLink9Description: https://datasheet.ciiva.com/48531/aoa0000ce2-48531070.pdf?src-supplier=Avnet; ComponentLink9URL: https://datasheet.ciiva.com/48531/aoa0000ce2-48531070.pdf?src-supplier=Avnet; ComponentLink10Description: https://datasheet.ciiva.com/9186/aoa0000ce2-9186687.pdf?src-supplier=Avnet; ComponentLink10URL: https://datasheet.ciiva.com/9186/aoa0000ce2-9186687.pdf?src-supplier=Avnet; ComponentLink11Description: https://datasheet.ciiva.com/16778/70260748-16778055.pdf?src-supplier=Allied+Electronics; ComponentLink11URL: https://datasheet.ciiva.com/16778/70260748-16778055.pdf?src-supplier=Allied+Electronics; ComponentLink12Description: https://datasheet.ciiva.com/30245/aoa0000ce2-30245691.pdf?src-supplier=Onlinecomponents.com; ComponentLink12URL: https://datasheet.ciiva.com/30245/aoa0000ce2-30245691.pdf?src-supplier=Onlinecomponents.com; ComponentLink13Description: https://datasheet.ciiva.com/7830/426256-7830974.pdf?src-supplier=Element14; ComponentLink13URL: https://datasheet.ciiva.com/7830/426256-7830974.pdf?src-supplier=Element14; ComponentLink14Description: https://datasheet.ciiva.com/16784/70260748-16784190.pdf?src-supplier=Allied+Electronics; ComponentLink14URL: https://datasheet.ciiva.com/16784/70260748-16784190.pdf?src-supplier=Allied+Electronics; ComponentLink15Description: https://datasheet.ciiva.com/22723/426256-22723902.pdf?src-supplier=Element14; ComponentLink15URL: https://datasheet.ciiva.com/22723/426256-22723902.pdf?src-supplier=Element14; Composition: Thick Film; Contact Plating: Tin; DatasheetVersion: 04/2015; Depth: 0.5mm; Features: Automotive AEC-Q200; Height: 0.35 mm; Lead Free: Lead Free; Length: 1 mm; Library Name: Altium Content Vault; Manufacturer Part Number: ERJ-2RKF1003X; Max Operating Temperature: 155 degC; Military Standard: Not; Min Operating Temperature: -55 degC; Mount: Surface Mount; Mounting Technology: Surface Mount; Number of Pins: 2; Number of Terminations: 2; Package Quantity: 10000; PackageDescription: 2-Pin Surface Mount Device, Body 1 x 0.5 mm; PackageReference: 0402; Packaging: Tape and Reel; PartNumber: ERJ-2RKF1003X; Pins: 2; Pricing 1: 1=0,10000, 10=0,04500, 100=0,01800, 1000=0,00800, 2500=0,00500, 10000=0,00400 (USD); Radiation Hardening: No; REACH SVHC: Yes; RoHS: Yes; RoHSCompliant: true; Stock 1: 1581193; Supplier 1: Mouser; Supplier Part Number 1: 667-ERJ-2RKF1003X; Termination: SMD/SMT; Tolerance: 1%; Width: 0.5 mm
U1-U3 = CMP-0870-00003-1: 12-Bit Rotary Position Sensor with Digital Interface, 3.3 or 5 V, -40 to 125 degC, SSOP-16, Pb-Free, Reel; Manufacturer: AMS; Built in Switch: No; Encoder Type: Magnetic; Output: SSI, PWM, Incremental A/B/Index; Resolution: 12 bit; Supply Voltage (V): 3.3 or 5.0; Case/Package: SSOP; Category: Integrated Circuits (ICs); Component Kind: Standard; ComponentLink1Description: Manufacturer URL; ComponentLink1URL: www.ams.com; ComponentLink2Description: Datasheet; ComponentLink2URL: http://www.ams.com/eng/content/download/438063/1340357/246195; ComponentLink3Description: https://ams.com/documents/20143/36005/AS5045B_DS000397_2-00.pdf?src-supplier=Digi-Key; ComponentLink3URL: https://ams.com/documents/20143/36005/AS5045B_DS000397_2-00.pdf?src-supplier=Digi-Key; ComponentLink4Description: http://ams.com/documents/20143/36005/AS5045B_DS000397_2-00.pdf?src-supplier=Digi-Key; ComponentLink4URL: http://ams.com/documents/20143/36005/AS5045B_DS000397_2-00.pdf?src-supplier=Digi-Key; ComponentLink5Description: http://ams.com/eng/content/download/438063/1340357/AS5045B_Datasheet.pdf?src-supplier=Digi-Key; ComponentLink5URL: http://ams.com/eng/content/download/438063/1340357/AS5045B_Datasheet.pdf?src-supplier=Digi-Key; DatasheetVersion: Rev. 1.0; Detent: No; Features: Zero position programming, diagnostic features, daisy chain mode, chip- ID, etc.; Lead Free: Lead Free; Library Name: Altium Content Vault; Manufacturer Part Number: AS5045B-ASSM; Mounting Technology: Surface Mount; Number of Pins: 16; PackageDescription: 16-Pin Shrink Small Outline Package, 6.2 x 5.3 mm Body, 0.65 mm Pitch; PackageReference: SSOP-16; PackageVersion: Rev. 1.7, 06/2010; PartNumber: AS5045B-ASSM; Pricing 1: 1=14,77000, 10=12,31000, 25=11,38000, 50=11,07000, 100=9,53000, 250=9,23000 (USD); RoHS: Yes; Stock 1: 842; Supplier 1: Mouser; Supplier Part Number 1: 985-AS5045B-ASSM; Termination: SMD/SMT
U4-U6 = DRV8876RGTR: H-Bridge Motor Driver With Integrated Current Sense and  Regulation; Manufacturer: Texas Instruments; Component Kind: Standard; Manufacturer Part Number: DRV8876RGTR; Pricing 1: 1=1,81000, 10=1,54000, 100=1,11000, 500=1,07000, 1000=0,85600, 3000=0,80000 (USD); RoHS: No; Stock 1: 2990; Supplier 1: Mouser; Supplier Part Number 1: 595-DRV8876RGTR; Library Name: IntegratedSchematicLib_OrbitaProject.SchLib
U10 = CMP-0187-00462-2: 1 MHz, Low-Power Operational Amplifier, 5-Pin SOT-23, Industrial Temperature, Tape and Reel; Manufacturer: Microchip; Category: Amplifiers - Op Amps, Buffer, Instrumentation; Code_IPC: SOT23-5; Component Kind: Standard; ComponentLink1Description: Manufacturer URL; ComponentLink1URL: http://www.microchip.com/; ComponentLink2Description: Datasheet; ComponentLink2URL: http://ww1.microchip.com/downloads/en/DeviceDoc/21733j.pdf; ComponentLink3Description: Package Specification; ComponentLink3URL: http://www.microchip.com/stellent/groups/techpub_sg/documents/packagingspec/en012702.pdf; ComponentLink4Description: https://datasheet.ciiva.com/1793/21733j-1793040.pdf?src-supplier=Digi-Key; ComponentLink4URL: https://datasheet.ciiva.com/1793/21733j-1793040.pdf?src-supplier=Digi-Key; ComponentLink5Description: https://datasheet.ciiva.com/16199/filehandler-aspxddocname-en011705-16199408.pdf?src-supplier=Future+Electronics; ComponentLink5URL: https://datasheet.ciiva.com/16199/filehandler-aspxddocname-en011705-16199408.pdf?src-supplier=Future+Electronics; ComponentLink6Description: https://datasheet.ciiva.com/26344/651487-da-01-en-ic-opamp-mcp6001t-i-ot-sot-23-5-mcp-26344500.pdf?src-supplier=Conrad; ComponentLink6URL: https://datasheet.ciiva.com/26344/651487-da-01-en-ic-opamp-mcp6001t-i-ot-sot-23-5-mcp-26344500.pdf?src-supplier=Conrad; ComponentLink7Description: https://datasheet.ciiva.com/1793/21733j-1793041.pdf?src-supplier=Digi-Key; ComponentLink7URL: https://datasheet.ciiva.com/1793/21733j-1793041.pdf?src-supplier=Digi-Key; ComponentLink8Description: https://datasheet.ciiva.com/1793/21733j-1793042.pdf?src-supplier=Digi-Key; ComponentLink8URL: https://datasheet.ciiva.com/1793/21733j-1793042.pdf?src-supplier=Digi-Key; ComponentLink9Description: https://datasheet.ciiva.com/14151/mcp6001-2-4-eng-tds-14151487.pdf?src-supplier=Distrelec; ComponentLink9URL: https://datasheet.ciiva.com/14151/mcp6001-2-4-eng-tds-14151487.pdf?src-supplier=Distrelec; ComponentLink10Description: https://datasheet.ciiva.com/16796/jp011705-16796421.pdf?src-supplier=Avnet+Europe; ComponentLink10URL: https://datasheet.ciiva.com/16796/jp011705-16796421.pdf?src-supplier=Avnet+Europe; ComponentLink11Description: https://datasheet.ciiva.com/8078/524878-8078826.pdf?src-supplier=Element14; ComponentLink11URL: https://datasheet.ciiva.com/8078/524878-8078826.pdf?src-supplier=Element14; ComponentLink12Description: https://datasheet.ciiva.com/14544/70045422-14544795.pdf?src-supplier=Allied+Electronics; ComponentLink12URL: https://datasheet.ciiva.com/14544/70045422-14544795.pdf?src-supplier=Allied+Electronics; ComponentLink13Description: https://datasheet.ciiva.com/8078/524878-8078829.pdf?src-supplier=Element14; ComponentLink13URL: https://datasheet.ciiva.com/8078/524878-8078829.pdf?src-supplier=Element14; DatasheetVersion: revJ, Nov-2009; Library Name: Altium Content Vault; Manufacturer Part Number: MCP6001T-I/OT; PackageDescription: 5-Lead Plastic Small Outline Transistor (OT) [SOT-23]; PackageReference: SOT-23-OT5; PackageVersion: revBB, Aug-2009; Pricing 1: 1=0,24000, 10=0,24000, 25=0,20000, 100=0,18000, 250=0,18000, 500=0,18000 (USD); RevisionNote: URLs added.; RoHS: Yes; Stock 1: 108756; Supplier 1: Mouser; Supplier Part Number 1: 579-MCP6001T-I/OT
